# Altium schematic sheet BLUE_TOOTH.SchDoc(BLUE_TOOTH)
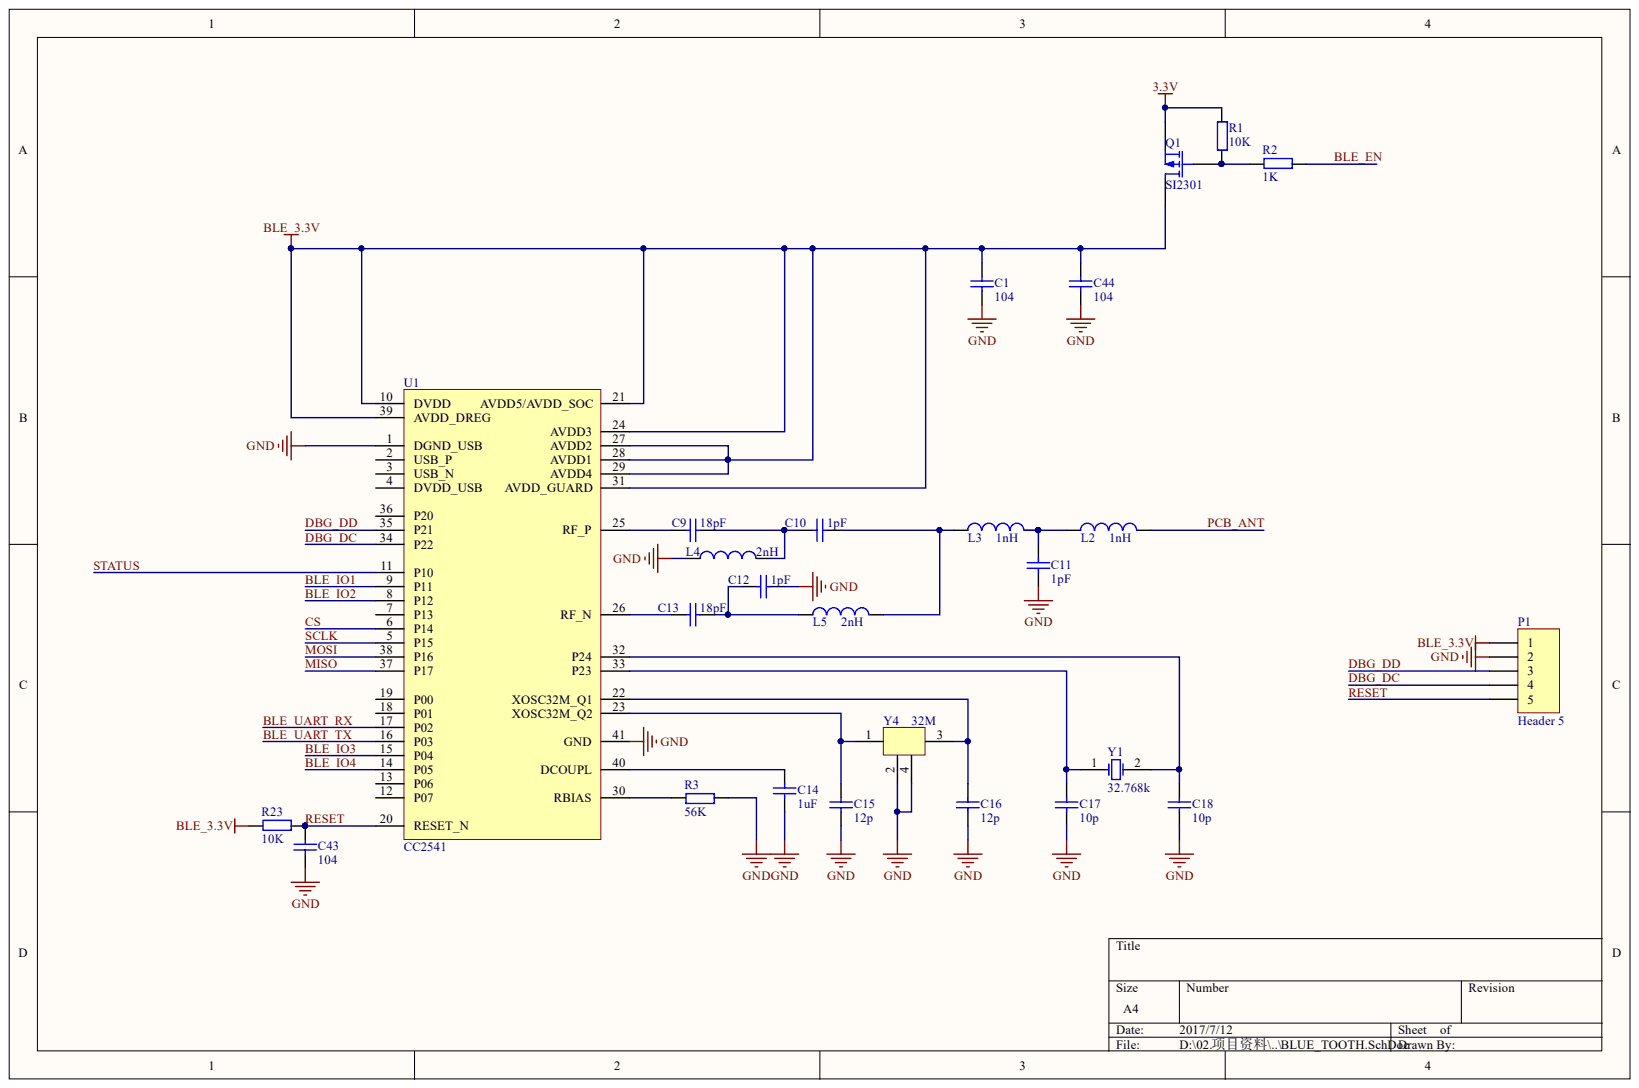
[diagram p0: BLUE_TOOTH.SchDoc]

## Parts
C1  104  [0603P]
C9  18pF  [0603P]
C10  1pF  [0603P]
C11  1pF  [0603P]
C12  1pF  [0603P]
C13  18pF  [0603P]
C14  1uF  [0603P]
C15  12p  [0603P]
C16  12p  [0603P]
C17  10p  [0603P]
C18  10p  [0603P]
C43  104  [0603P]
C44  104  [0603P]
L2  1nH  [0603P]
L3  1nH  [0603P]
L4  2nH  [0603P]
L5  2nH  [0603P]
P1  Header 5  [HDR1X5]
Q1  SI2301  [SOT-23_P]
R1  10K  [0603P]
R2  1K  [0603P]
R3  56K  [0603P]
R23  10K  [0603P]
U1  CC2541  [QFN_40]
Y1  32.768  value 32.768k  [XTAL_3215]
Y4  32M  [XTAL_4p]

## Nets
3.3V: Q1.3 R1.2
BLE_3.3V: C1.2 C44.2 P1.1 Q1.1 R23.2 U1.10(DVDD) U1.21(AVDD5/AVDD_SOC) U1.24(AVDD3) U1.27(AVDD2) U1.28(AVDD1) U1.29(AVDD4) U1.31(AVDD_GUARD) U1.39(AVDD_DREG)
GND: C1.1 C11.1 C12.1 C14.1 C15.1 C16.1 C17.1 C18.1 C43.1 C44.1 L4.1 P1.2 R3.2 U1.1(DGND_USB) U1.41(GND) Y4.2 Y4.4
BLE_EN: R2.1
BLE_IO1: U1.9(P11)
BLE_IO2: U1.8(P12)
BLE_IO3: U1.15(P04)
BLE_IO4: U1.14(P05)
BLE_UART_RX: U1.17(P02)
BLE_UART_TX: U1.16(P03)
CS: U1.6(P14)
DBG_DC: P1.4 U1.34(P22)
DBG_DD: P1.3 U1.35(P21)
MISO: U1.37(P17)
MOSI: U1.38(P16)
PCB_ANT: L2.2
RESET: C43.2 P1.5 R23.1 U1.20(RESET_N)
SCLK: U1.5(P15)
STATUS: U1.11(P10)
NetC9_1: C9.1 C10.2 L4.2
NetC9_2: C9.2 U1.25(RF_P)
NetC10_1: C10.1 L3.1 L5.2
NetC11_2: C11.2 L2.1 L3.2
NetC12_2: C12.2 C13.1 L5.1
NetC13_2: C13.2 U1.26(RF_N)
NetC14_2: C14.2 U1.40(DCOUPL)
NetC15_2: C15.2 U1.23(XOSC32M_Q2) Y4.1
NetC16_2: C16.2 U1.22(XOSC32M_Q1) Y4.3
NetC17_2: C17.2 U1.33(P23) Y1.1
NetC18_2: C18.2 U1.32(P24) Y1.2
NetQ1_2: Q1.2 R1.1 R2.2
NetR3_1: R3.1 U1.30(RBIAS)

Not connected: U1 2(USB_P) 3(USB_N) 4(DVDD_USB) 7(P13) 12(P07) 13(P06) 18(P01) 19(P00) 36(P20)

## Library data of the parts
C1 C43 C44 = Cap: Capacitor; Component Kind: Standard; LatestRevisionDate: 17-Jul-2002; LatestRevisionNote: Re-released for DXP Platform.; Library Name: smart_home_main_Project.SCHLIB; PackageDescription: Radial Cap, Thru-Hole; 2 Leads; 0.3 in Pin Spacing; PackageReference: RAD-0.3; Published: 8-Jun-2000; Signal Integrity: Cap; Simulation: CAP; Value: 104; Publisher: Altium Limited
C9-C18 = Cap: Capacitor; Value: 104; Component Kind: Standard; LatestRevisionDate: 17-Jul-2002; LatestRevisionNote: Re-released for DXP Platform.; Library Name: smart_home_main_Project.SCHLIB; PackageDescription: Radial Cap, Thru-Hole; 2 Leads; 0.3 in Pin Spacing; PackageReference: RAD-0.3; Published: 8-Jun-2000; Signal Integrity: Cap; Simulation: CAP; Publisher: Altium Limited
L2-L5 = Inductor: Code_IEC: 1005; Code_JEITA: 0402; Component Kind: Standard; Description: Inductor; LatestRevisionDate: 29-May-2009; LatestRevisionNote: IPC-7351 Footprint Added.; Library Name: M4.IntLib; PackageDescription: Chip Inductor; PackageReference: 0402-A; Published: 8-Jun-2000; Publisher: Altium Limited
  L2 L3: Value: 1nH
  L4 L5: Value: 2nH
P1 = Header 5: Header, 5-Pin; Component Kind: Standard; LatestRevisionDate: 17-Jul-2002; LatestRevisionNote: Re-released for DXP Platform.; Library Name: Miscellaneous Connectors.IntLib; Signal Integrity: Connector; Publisher: Altium Limited
Q1 = MOSFET-P: P-Channel MOSFET; Component Kind: Standard; LatestRevisionDate: 17-Jul-2002; LatestRevisionNote: Re-released for DXP Platform.; Library Name: Miscellaneous Devices.IntLib; PackageDescription: TO, Flat Index; 3 In-Line, Axial Leads; Body Dia. 4.6mm; Lead Dia. 0.5 mm (max); PackageReference: E3; PackageVersion: 1995/1996; Published: 8-Jun-2000; Publisher: Altium Limited
R1-R3 R23 = R: Component Kind: Standard; Library Name: smart_home_main_Project.SCHLIB
Y1 = XTAL: Crystal Oscillator; Component Kind: Standard; LatestRevisionDate: 17-Jul-2002; LatestRevisionNote: Re-released for DXP Platform.; Library Name: M4.IntLib; PackageDescription: Crystal, Thru-Hole; 2 Leads; Body 3.1 x 8.2 mm (Dia.xH); PackageReference: R38; Published: 8-Jun-2000; Publisher: Altium Limited
Y4 = XTAL_4: Component Kind: Standard; Library Name: Y1.SchLib
U1 = CC2541: Component Kind: Standard; Library Name: xyd_watch.SCHLIB
